# Altium schematic sheet 2_K230.schdoc(2_K230)
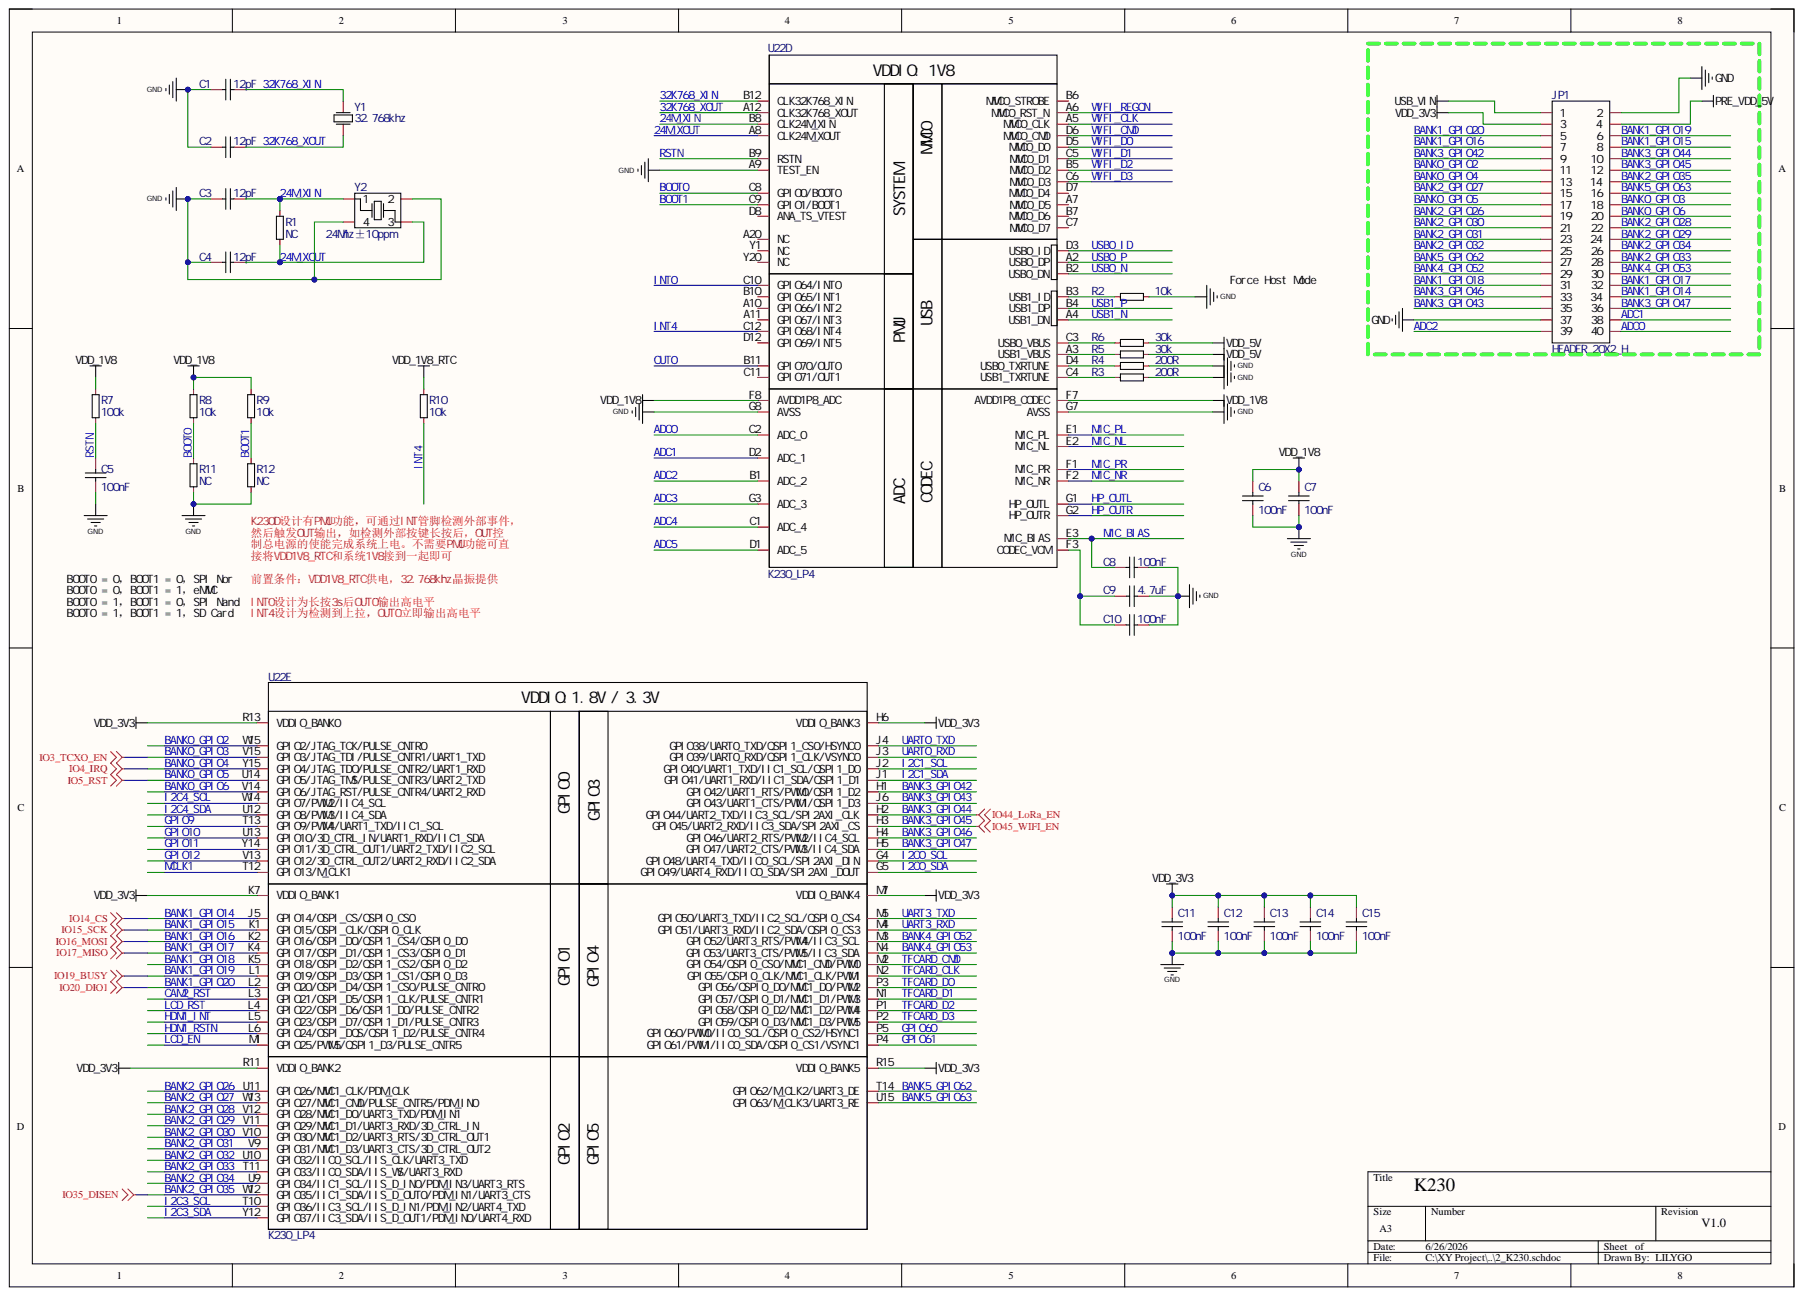
[diagram p0: 2_K230.schdoc]

## Parts
C1  12pF  [c0402]
C2  12pF  [c0402]
C3  12pF  [c0402]
C4  12pF  [c0402]
C5  100nF  [c0402]
C6  100nF  [C0201]
C7  100nF  [C0201]
C8  100nF  [c0402]
C9  4.7uF  [c0402]
C10  100nF  [c0402]
C11  100nF  [C0201]
C12  100nF  [C0201]
C13  100nF  [C0201]
C14  100nF  [C0201]
C15  100nF  [C0201]
JP1  HEADER_20X2_H  [HEADER_2X20_H_F_2.54MM]
R1  NC  [r0402]
R2  10k  [r0402]
R3  200R  [r0402]
R4  200R  [r0402]
R5  30k  [r0402]
R6  30k  [r0402]
R7  100k  [r0402]
R8  10k  [r0402]
R9  10k  [r0402]
R10  10k  [r0402]
R11  NC  [r0402]
R12  NC  [r0402]
U22  K230_LP4  [K230]  (parts D,E)
Y1  32.768khz  [CRYSTAL-SMD_L3.2-W1.5_RT3215]
Y2  24Mhz±10ppm  [XTAL_3225]

## Nets
GND: C1.1 C2.1 C3.1 C4.1 C5.2 C6.2 C7.2 C8.2 C9.2 C10.2 C11.2 C12.2 C13.2 C14.2 C15.2 JP1.2 JP1.37 R2.2 R3.2 R4.2 R11.2 R12.2 U22.A9(TEST_EN) U22.G7(AVSS) U22.G8(AVSS) Y2.2 Y2.4
PRE_VDD_5V: JP1.4
USB_VIN: JP1.1
VDD_1V8: C6.1 C7.1 R7.1 R8.1 R9.1 U22.F7(AVDD1P8_CODEC) U22.F8(AVDD1P8_ADC)
VDD_1V8_RTC: R10.1
VDD_3V3: C11.1 C12.1 C13.1 C14.1 C15.1 JP1.3 U22.H6(VDDIO_BANK3) U22.K7(VDDIO_BANK1) U22.M7(VDDIO_BANK4) U22.R11(VDDIO_BANK2) U22.R13(VDDIO_BANK0) U22.R15(VDDIO_BANK5)
VDD_5V: R5.2 R6.2
24M_XIN: C3.2 R1.1 U22.B8(CLK24M_XIN) Y2.1
24M_XOUT: C4.2 R1.2 U22.A8(CLK24M_XOUT) Y2.3
32K768_XIN: C1.2 U22.B12(CLK32K768_XIN) Y1.2
32K768_XOUT: C2.2 U22.A12(CLK32K768_XOUT) Y1.1
ADC0: JP1.40 U22.C2(ADC_0)
ADC1: JP1.38 U22.D2(ADC_1)
ADC2: JP1.39 U22.B1(ADC_2)
ADC3: U22.G3(ADC_3)
ADC4: U22.C1(ADC_4)
ADC5: U22.D1(ADC_5)
BANK0_GPIO2: JP1.11 U22.W15(GPIO2/JTAG_TCK/PULSE_CNTR0)
BANK0_GPIO3: JP1.18 U22.V15(GPIO3/JTAG_TDI/PULSE_CNTR1/UART1_TXD)
BANK0_GPIO4: JP1.13 U22.Y15(GPIO4/JTAG_TDO/PULSE_CNTR2/UART1_RXD)
BANK0_GPIO5: JP1.17 U22.U14(GPIO5/JTAG_TMS/PULSE_CNTR3/UART2_TXD)
BANK0_GPIO6: JP1.20 U22.V14(GPIO6/JTAG_RST/PULSE_CNTR4/UART2_RXD)
BANK1_GPIO14: JP1.34 U22.J5(GPIO14/OSPI_CS/QSPI0_CS0)
BANK1_GPIO15: JP1.8 U22.K1(GPIO15/OSPI_CLK/QSPI0_CLK)
BANK1_GPIO16: JP1.7 U22.K2(GPIO16/OSPI_D0/QSPI1_CS4/QSPI0_D0)
BANK1_GPIO17: JP1.32 U22.K4(GPIO17/OSPI_D1/QSPI1_CS3/QSPI0_D1)
BANK1_GPIO18: JP1.31 U22.K5(GPIO18/OSPI_D2/QSPI1_CS2/QSPI0_D2)
BANK1_GPIO19: JP1.6 U22.L1(GPIO19/OSPI_D3/QSPI1_CS1/QSPI0_D3)
BANK1_GPIO20: JP1.5 U22.L2(GPIO20/OSPI_D4/QSPI1_CS0/PULSE_CNTR0)
BANK2_GPIO26: JP1.19 U22.U11(GPIO26/MMC1_CLK/PDM_CLK)
BANK2_GPIO27: JP1.15 U22.W13(GPIO27/MMC1_CMD/PULSE_CNTR5/PDM_IN0)
BANK2_GPIO28: JP1.22 U22.V12(GPIO28/MMC1_D0/UART3_TXD/PDM_IN1)
BANK2_GPIO29: JP1.24 U22.V11(GPIO29/MMC1_D1/UART3_RXD/3D_CTRL_IN)
BANK2_GPIO30: JP1.21 U22.V10(GPIO30/MMC1_D2/UART3_RTS/3D_CTRL_OUT1)
BANK2_GPIO31: JP1.23 U22.V9(GPIO31/MMC1_D3/UART3_CTS/3D_CTRL_OUT2)
BANK2_GPIO32: JP1.25 U22.U10(GPIO32/IIC0_SCL/IIS_CLK/UART3_TXD)
BANK2_GPIO33: JP1.28 U22.T11(GPIO33/IIC0_SDA/IIS_WS/UART3_RXD)
BANK2_GPIO34: JP1.26 U22.U9(GPIO34/IIC1_SCL/IIS_D_IN0/PDM_IN3/UART3_RTS)
BANK2_GPIO35: JP1.14 U22.W12(GPIO35/IIC1_SDA/IIS_D_OUT0/PDM_IN1/UART3_CTS)
BANK3_GPIO42: JP1.9 U22.H1(GPIO42/UART1_RTS/PWM0/QSPI1_D2)
BANK3_GPIO43: JP1.35 U22.J6(GPIO43/UART1_CTS/PWM1/QSPI1_D3)
BANK3_GPIO44: JP1.10 U22.H2(GPIO44/UART2_TXD/IIC3_SCL/SPI2AXI_CLK)
BANK3_GPIO45: JP1.12 U22.H3(GPIO45/UART2_RXD/IIC3_SDA/SPI2AXI_CS)
BANK3_GPIO46: JP1.33 U22.H4(GPIO46/UART2_RTS/PWM2/IIC4_SCL)
BANK3_GPIO47: JP1.36 U22.H5(GPIO47/UART2_CTS/PWM3/IIC4_SDA)
BANK4_GPIO52: JP1.29 U22.M3(GPIO52/UART3_RTS/PWM4/IIC3_SCL)
BANK4_GPIO53: JP1.30 U22.N4(GPIO53/UART3_CTS/PWM5/IIC3_SDA)
BANK5_GPIO62: JP1.27 U22.T14(GPIO62/M_CLK2/UART3_DE)
BANK5_GPIO63: JP1.16 U22.U15(GPIO63/M_CLK3/UART3_RE)
BOOT0: R8.2 R11.1 U22.C8(GPIO0/BOOT0)
BOOT1: R9.2 R12.1 U22.C9(GPIO1/BOOT1)
CAM2_RST: U22.L3(GPIO21/OSPI_D5/QSPI1_CLK/PULSE_CNTR1)
GPIO9: U22.T13(GPIO9/PWM4/UART1_TXD/IIC1_SCL)
GPIO10: U22.U13(GPIO10/3D_CTRL_IN/UART1_RXD/IIC1_SDA)
GPIO11: U22.Y14(GPIO11/3D_CTRL_OUT1/UART2_TXD/IIC2_SCL)
GPIO12: U22.V13(GPIO12/3D_CTRL_OUT2/UART2_RXD/IIC2_SDA)
GPIO60: U22.P5(GPIO60/PWM0/IIC0_SCL/QSPI0_CS2/HSYNC1)
GPIO61: U22.P4(GPIO61/PWM1/IIC0_SDA/QSPI0_CS1/VSYNC1)
HDMI_INT: U22.L5(GPIO23/OSPI_D7/QSPI1_D1/PULSE_CNTR3)
HDMI_RSTN: U22.L6(GPIO24/OSPI_DQS/QSPI1_D2/PULSE_CNTR4)
HP_OUTL: U22.G1(HP_OUTL)
HP_OUTR: U22.G2(HP_OUTR)
I2C0_SCL: U22.G4(GPIO48/UART4_TXD/IIC0_SCL/SPI2AXI_DIN)
I2C0_SDA: U22.G5(GPIO49/UART4_RXD/IIC0_SDA/SPI2AXI_DOUT)
I2C1_SCL: U22.J2(GPIO40/UART1_TXD/IIC1_SCL/QSPI1_D0)
I2C1_SDA: U22.J1(GPIO41/UART1_RXD/IIC1_SDA/QSPI1_D1)
I2C3_SCL: U22.T10(GPIO36/IIC3_SCL/IIS_D_IN1/PDM_IN2/UART4_TXD)
I2C3_SDA: U22.Y12(GPIO37/IIC3_SDA/IIS_D_OUT1/PDM_IN0/UART4_RXD)
I2C4_SCL: U22.W14(GPIO7/PWM2/IIC4_SCL)
I2C4_SDA: U22.U12(GPIO8/PWM3/IIC4_SDA)
INT0: U22.C10(GPIO64/INT0)
INT4: R10.2 U22.C12(GPIO68/INT4)
LCD_EN: U22.M1(GPIO25/PWM5/QSPI1_D3/PULSE_CNTR5)
LCD_RST: U22.L4(GPIO22/OSPI_D6/QSPI1_D0/PULSE_CNTR2)
MCLK1: U22.T12(GPIO13/M_CLK1)
MIC_BIAS: C8.1 U22.E3(MIC_BIAS)
MIC_NL: U22.E2(MIC_NL)
MIC_NR: U22.F2(MIC_NR)
MIC_PL: U22.E1(MIC_PL)
MIC_PR: U22.F1(MIC_PR)
OUT0: U22.B11(GPIO70/OUT0)
RSTN: C5.1 R7.2 U22.B9(RSTN)
TFCARD_CLK: U22.N2(GPIO55/QSPI0_CLK/MMC1_CLK/PWM1)
TFCARD_CMD: U22.M2(GPIO54/QSPI0_CS0/MMC1_CMD/PWM0)
TFCARD_D0: U22.P3(GPIO56/QSPI0_D0/MMC1_D0/PWM2)
TFCARD_D1: U22.N1(GPIO57/QSPI0_D1/MMC1_D1/PWM3)
TFCARD_D2: U22.P1(GPIO58/QSPI0_D2/MMC1_D2/PWM4)
TFCARD_D3: U22.P2(GPIO59/QSPI0_D3/MMC1_D3/PWM5)
UART0_RXD: U22.J3(GPIO39/UART0_RXD/QSPI1_CLK/VSYNC0)
UART0_TXD: U22.J4(GPIO38/UART0_TXD/QSPI1_CS0/HSYNC0)
UART3_RXD: U22.M4(GPIO51/UART3_RXD/IIC2_SDA/QSPI0_CS3)
UART3_TXD: U22.M5(GPIO50/UART3_TXD/IIC2_SCL/QSPI0_CS4)
USB0_ID: U22.D3(USB0_ID)
USB0_N: U22.B2(USB0_DN)
USB0_P: U22.A2(USB0_DP)
USB1_N: U22.A4(USB1_DN)
USB1_P: U22.B4(USB1_DP)
WIFI_CLK: U22.A5(MMC0_CLK)
WIFI_CMD: U22.D6(MMC0_CMD)
WIFI_D0: U22.D5(MMC0_D0)
WIFI_D1: U22.C5(MMC0_D1)
WIFI_D2: U22.B5(MMC0_D2)
WIFI_D3: U22.C6(MMC0_D3)
WIFI_REGON: U22.A6(MMC0_RST_N)
NetC9_1: C9.1 C10.1 U22.F3(CODEC_VCM)
NetR2_1: R2.1 U22.B3(USB1_ID)
NetR3_1: R3.1 U22.C4(USB1_TXRTUNE)
NetR4_1: R4.1 U22.D4(USB0_TXRTUNE)
NetR5_1: R5.1 U22.A3(USB1_VBUS)
NetR6_1: R6.1 U22.C3(USB0_VBUS)

Not connected: U22 A7(MMC0_D5) A10(GPIO66/INT2) A11(GPIO67/INT3) A20(NC) B6(MMC0_STROBE) B7(MMC0_D6) B10(GPIO65/INT1) C7(MMC0_D7) C11(GPIO71/OUT1) D7(MMC0_D4) D8(ANA_TS_VTEST) D12(GPIO69/INT5) Y1(NC) Y20(NC)

## Library data of the parts
C1-C5 C8-C10 = CAP: Add into BOM: yes; Component Kind: Standard; Convert to PCB: yes; Device: CAP; Symbol: CAP
  C1-C4: Comment_1: 12pF
  C5 C8 C10: Comment_1: 100nF
  C9: Comment_1: 4.7uF
C6 C7 C11-C15 = 0201_CAP: Add into BOM: yes; Symbol: CAP; Comment_1: 100nF; Component Kind: Standard; Convert to PCB: yes; Device: 0201_CAP; PCB3D: 0201-CAP
JP1 = HEADER_2x20_H_F_2.54MM: 2x20 水平排序排针,2.54mm间距; Add into BOM: yes; Comment_1: HEADER_20X2_H; Component Kind: Standard; Convert to PCB: yes; Device: HEADER_2x20_H_F_2.54MM; PCB3D: 双排针-2x20P-2.54mm; Symbol: HEADER_20X2_H
R1-R12 = RES: Add into BOM: yes; Component Kind: Standard; Convert to PCB: yes; Device: RES; Symbol: RES
  R1 R11 R12: Comment_1: NC
  R2 R8-R10: Comment_1: 10k
  R3 R4: Comment_1: 200R
  R5 R6: Comment_1: 30k
  R7: Comment_1: 100k
U22 = K230_LP4: Add into BOM: yes; Comment_1: K230_LP4; Component Kind: Standard; Convert to PCB: yes; Device: K230_LP4; Library Name: CanMV-K230-LP4-V3.0.SCHLIB; PCB3D: K230; Symbol: K230_LP4
Y1 = CRYSTAL: Add into BOM: yes; Comment_1: 32.768khz; Component Kind: Standard; Convert to PCB: yes; Device: CRYSTAL; Symbol: CRYSTAL
Y2 = CRYSTAL2: Add into BOM: yes; Comment_1: 24Mhz±10ppm; Component Kind: Standard; Convert to PCB: yes; Device: CRYSTAL2; Symbol: CRYSTAL2
